# Altium schematic sheet MKIII.SchDoc(MKIII)
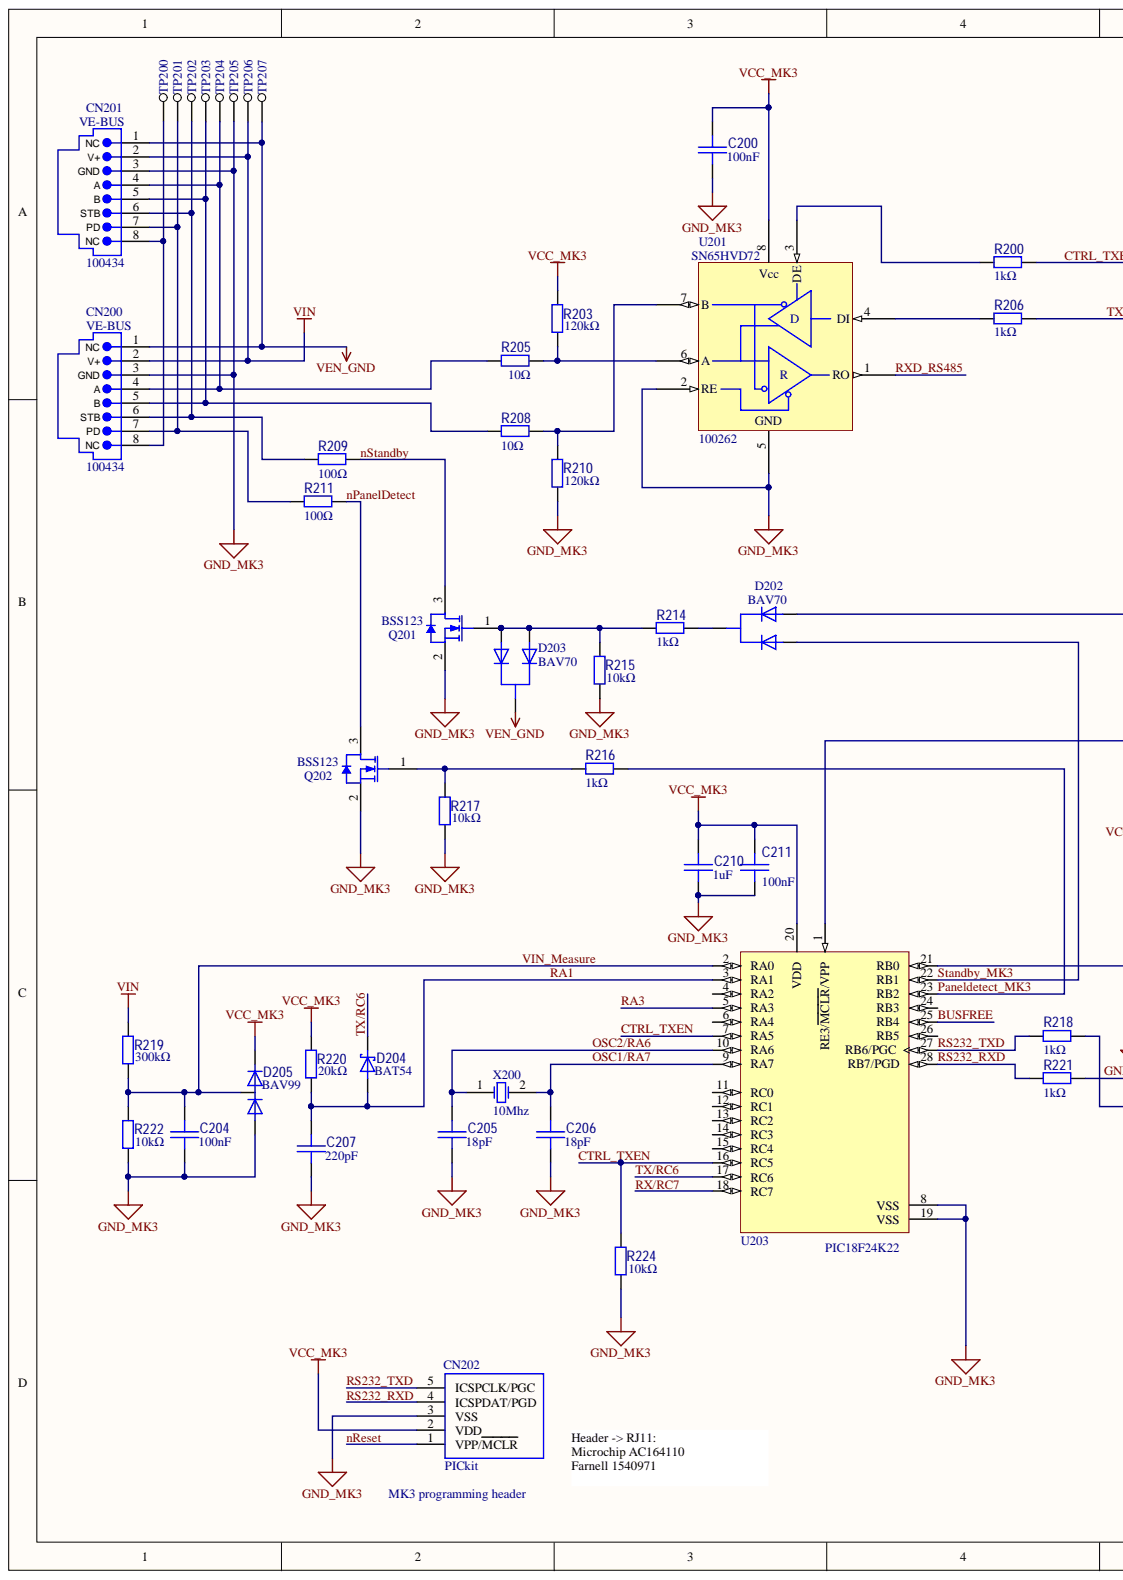
[diagram p0: MKIII.SchDoc, left]
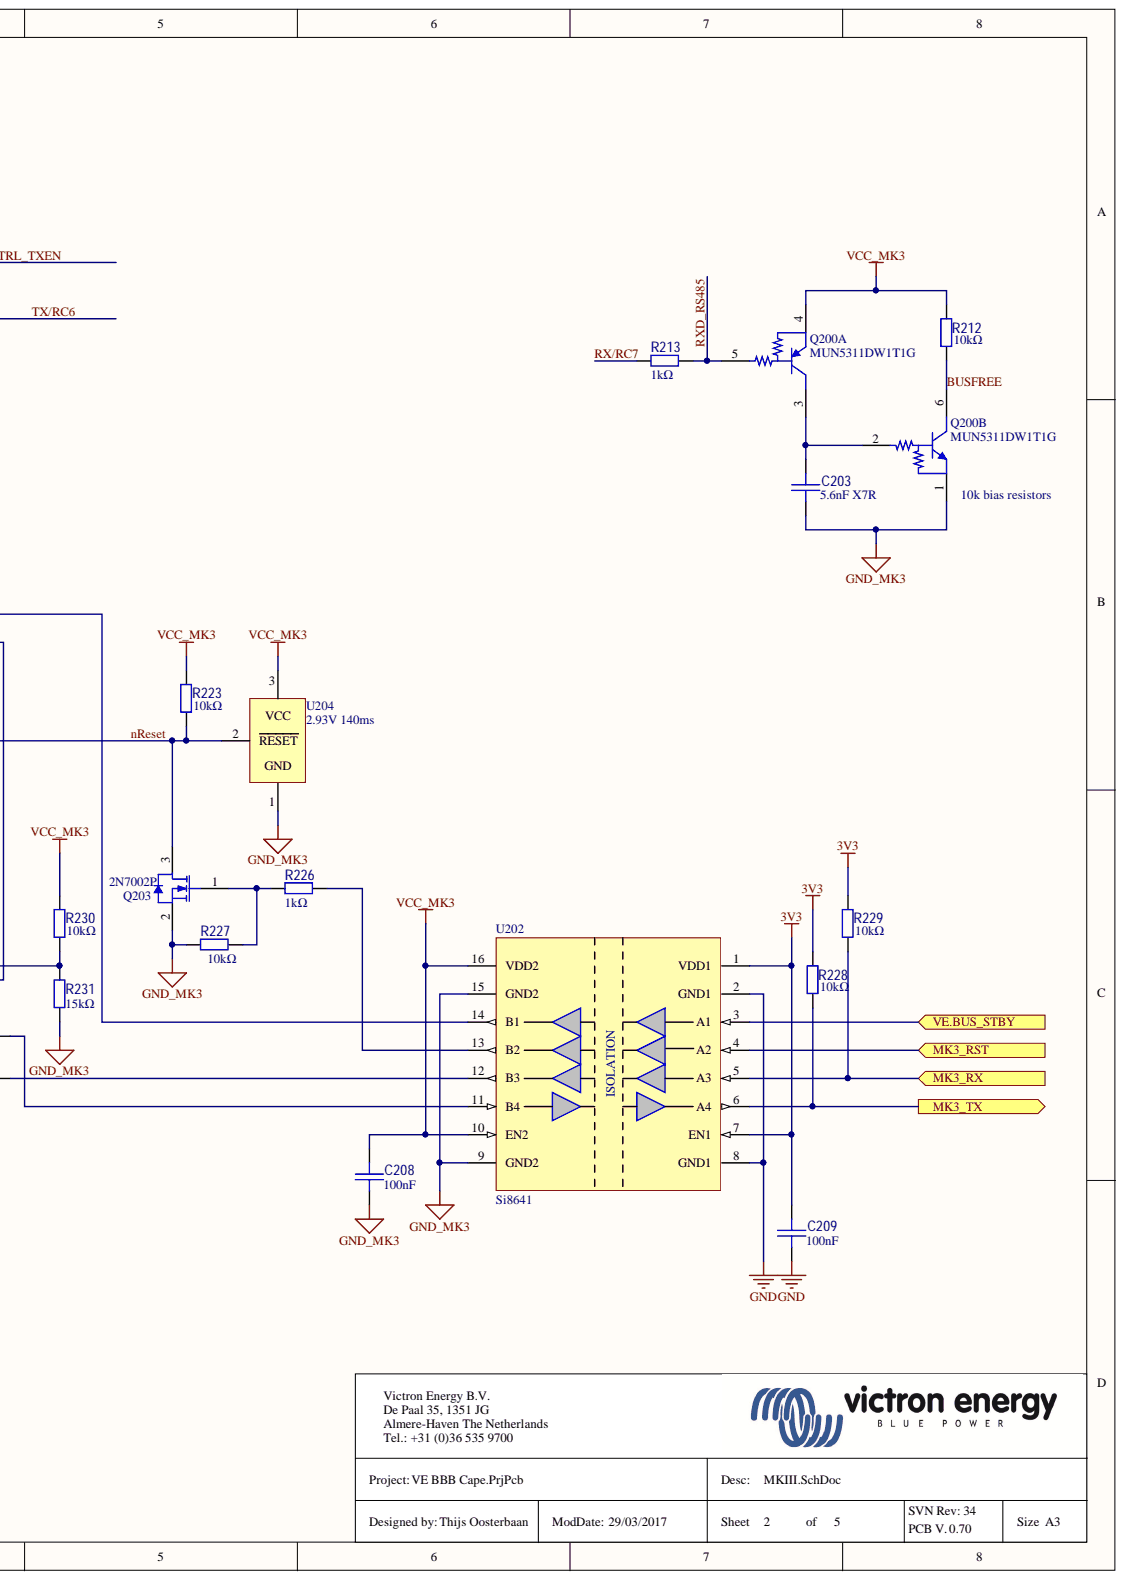
[diagram p1: MKIII.SchDoc, right]

## Parts
C200  value 100nF  [C0805_R]  (hidden comment: 1035)
C203  value 5.6nF X7R  [C0805_R]  (hidden comment: 100325)
C204  value 100nF  [C0805_R]  (hidden comment: 1035)
C205  value 18pF  [R0603_R]  (hidden comment: 1301)
C206  value 18pF  [R0603_R]  (hidden comment: 1301)
C207  value 220pF  [C0805_R]  (hidden comment: 1027)
C208  value 100nF  [C0805_R]  (hidden comment: 1035)
C209  value 100nF  [C0805_R]  (hidden comment: 1035)
C210  value 1uF  [C0805_R]  (hidden comment: 100185)
C211  value 100nF  [C0805_R]  (hidden comment: 1035)
CN200  100434  value VE-BUS  [95001-2881]
CN201  100434  value VE-BUS  [95001-2881]
CN202  value PICkit  [PRGHDR5]  (hidden comment: PICKIT_WITHOUTPIN6)
D202  BAV70  [SOT23_R]
D203  BAV70  [SOT23_R]
D204  BAT54  [SOT23_R]
D205  BAV99  [SOT23_R]
Q200  MUN5311DW1T1G  [SOT363]  (parts A,B)
Q201  BSS123  [SOT23_R]
Q202  BSS123  [SOT23_R]
Q203  2N7002P  [SOT23_R]
R200  value 1kΩ  [R0805_R]  (hidden comment: 372)
R203  value 120kΩ  [R0805_R]  (hidden comment: 422)
R205  value 10Ω  [R0805_R]  (hidden comment: 324)
R206  value 1kΩ  [R0805_R]  (hidden comment: 372)
R208  value 10Ω  [R0805_R]  (hidden comment: 324)
R209  value 100Ω  [R0805_R]  (hidden comment: 348)
R210  value 120kΩ  [R0805_R]  (hidden comment: 422)
R211  value 100Ω  [R0805_R]  (hidden comment: 348)
R212  value 10kΩ  [R0805_R]  (hidden comment: 396)
R213  value 1kΩ  [R0805_R]  (hidden comment: 372)
R214  value 1kΩ  [R0805_R]  (hidden comment: 372)
R215  value 10kΩ  [R0805_R]  (hidden comment: 396)
R216  value 1kΩ  [R0805_R]  (hidden comment: 372)
R217  value 10kΩ  [R0805_R]  (hidden comment: 396)
R218  value 1kΩ  [R0805_R]  (hidden comment: 372)
R219  value 300kΩ  [R0805_R]  (hidden comment: 431)
R220  value 20kΩ  [R0805_R]  (hidden comment: 403)
R221  value 1kΩ  [R0805_R]  (hidden comment: 372)
R222  value 10kΩ  [R0805_R]  (hidden comment: 396)
R223  value 10kΩ  [R0805_R]  (hidden comment: 396)
R224  value 10kΩ  [R0805_R]  (hidden comment: 396)
R226  value 1kΩ  [R0805_R]  (hidden comment: 372)
R227  value 10kΩ  [R0805_R]  (hidden comment: 396)
R228  value 10kΩ  [R0805_R]  (hidden comment: 396)
R229  value 10kΩ  [R0805_R]  (hidden comment: 396)
R230  value 10kΩ  [R0805_R]  (hidden comment: 396)
R231  value 15kΩ  [R0805_R]  (hidden comment: 400)
TP200  CN1  [testpad_round_1mm]  (value not drawn)  (no BOM)
TP201  CN1  [testpad_round_1mm]  (value not drawn)  (no BOM)
TP202  CN1  [testpad_round_1mm]  (value not drawn)  (no BOM)
TP203  CN1  [testpad_round_1mm]  (value not drawn)  (no BOM)
TP204  CN1  [testpad_round_1mm]  (value not drawn)  (no BOM)
TP205  CN1  [testpad_round_1mm]  (value not drawn)  (no BOM)
TP206  CN1  [testpad_round_1mm]  (value not drawn)  (no BOM)
TP207  CN1  [testpad_round_1mm]  (value not drawn)  (no BOM)
U201  100262  value SN65HVD72  [SOIC8]
U202  Si8641  [SO16_R]
U203  PIC18F24K22  [SSOP28_R]
U204  value 2.93V 140ms  [SOT23_R]  (hidden comment: MAX803)
X200  10Mhz  [ABMM2_DATASHEET]

## Ports
MK3_RST, MK3_RX, MK3_TX, VE.BUS_STBY

## Nets
3V3: C209.1 R228.1 R229.1 U202.1(VDD1) U202.7(EN1)
GND: C209.2 U202.2(GND1) U202.8(GND1)
GND_MK3: C200.2 C203.2 C204.2 C205.2 C206.2 C207.2 C208.2 C210.2 C211.2 CN200.3(GND) CN201.3(GND) CN202.3(VSS) D205.1 Q200.1 Q201.2 Q202.2 Q203.2 R210.2 R215.1 R217.1 R222.2 R224.2 R227.1 R231.2 TP205.1 U201.2(RE) U201.5 U202.9(GND2) U202.15(GND2) U203.8(VSS) U203.19(VSS) U204.1
VCC_MK3: C200.1 C208.1 C210.1 C211.1 CN202.2(VDD) D205.2 Q200.4 R203.1 R212.1 R220.1 R223.1 R230.1 U201.8 U202.10(EN2) U202.16(VDD2) U203.20(VDD) U204.3
VEN_GND: CN200.1(NC) CN201.1(NC) D203.3 TP207.1
VIN: CN200.2(V+) CN201.2(V+) R219.1 TP206.1
BUSFREE: Q200.6 R212.2 U203.25(RB4)
CTRL_TXEN: R200.1 R224.1 U203.7(RA5) U203.16(RC5)
MK3_RST: U202.4(A2) ; port MK3_RST
MK3_RX: R229.2 U202.5(A3) ; port MK3_RX
MK3_TX: R228.2 U202.6(A4) ; port MK3_TX
nPanelDetect: Q202.3 R211.2
nReset: CN202.1(VPP/MCLR) Q203.3 R223.2 U203.1(RE3/MCLR/VPP) U204.2
nStandby: Q201.3 R209.2
OSC1/RA7: C206.1 U203.9(RA7) X200.2
OSC2/RA6: C205.1 U203.10(RA6) X200.1
Paneldetect_MK3: R216.1 U203.23(RB2)
RA1: C207.1 D204.1 R220.2 U203.3(RA1)
RA3: U203.5(RA3)
RS232_RXD: CN202.4(ICSPDAT/PGD) R221.2 U203.28(RB7/PGD)
RS232_TXD: CN202.5(ICSPCLK/PGC) R218.2 U203.27(RB6/PGC)
RX/RC7: R213.2 U203.18(RC7)
RXD_RS485: Q200.5 R213.1 U201.1(RO)
Standby_MK3: D202.1 U203.22(RB1)
TX/RC6: D204.3 R206.1 U203.17(RC6)
VE.BUS_STBY: U202.3(A1) ; port VE.BUS_STBY
VIN_Measure: C204.1 D205.3 R219.2 R222.1 U203.2(RA0)
NetC203_1: C203.1 Q200.2 Q200.3
NetCN200_4: CN200.4(A) CN201.4(A) R205.1 TP204.1
NetCN200_5: CN200.5(B) CN201.5(B) R208.1 TP203.1
NetCN200_6: CN200.6(STB) CN201.6(STB) R209.1 TP202.1
NetCN200_7: CN200.7(PD) CN201.7(PD) R211.1 TP201.1
NetCN200_8: CN200.8(NC) CN201.8(NC) TP200.1
NetD202_2: D202.2 U202.14(B1)
NetD202_3: D202.3 R214.1
NetD203_1: D203.1 D203.2 Q201.1 R214.2 R215.2
NetQ202_1: Q202.1 R216.2 R217.2
NetQ203_1: Q203.1 R226.2 R227.2
NetR200_2: R200.2 U201.3(DE)
NetR203_2: R203.2 R205.2 U201.6(A)
NetR206_2: R206.2 U201.4(DI)
NetR208_2: R208.2 R210.1 U201.7(B)
NetR218_1: R218.1 U202.11(B4)
NetR221_1: R221.1 U202.12(B3)
NetR226_1: R226.1 U202.13(B2)
NetR230_2: R230.2 R231.1 U203.21(RB0)

Not connected: U203 4(RA2) 6(RA4) 11(RC0) 12(RC1) 13(RC2) 14(RC3) 15(RC4) 24(RB3) 26(RB5)

## Library data of the parts
C200 C204 C208 C209 C211 = 1035: Part_Description: CAP, SMD 0805 100nF 50V X7R 10%, Yageo; MANUFACTURER: Yageo; MAN_PARTNUMBER: CC0805KPX7R9BB104; INDIC_PARTNO: CSE100NF0805YAGY; Voltage: 50V; VENDOR_STOCK_NR: 603-CC805KPX7R9BB104; VENDOR_PRICE: 0.005; VENDOR: Mouser; Tol: 0.1; Shape: 805; INDIC_PRICE: 0.0682; Database Table Name: COMPDATA$; Cls: CAP; Part: 1035; Component Kind: Standard; Library Name: Data Base Librarys.DbLib
C203 = 100325: Part_Description: Cap, MLCC, X7R, 50V, 10%, 0805, 5.6nF; MANUFACTURER: Kemet; MAN_PARTNUMBER: C0805C562K5RACTU; VENDOR_STOCK_NR: 399-1156-1-ND; VENDOR_PRICE: 0.03025; VENDOR: Digikey; Database Table Name: COMPDATA$; Cls: CAP; Part: 100325; Component Kind: Standard; Library Name: Data Base Librarys.DbLib
C205 C206 = 1301: Part_Description: Cap, MLC, 0603, 18pF, 10%, X7R; MANUFACTURER: YAGEO; MAN_PARTNUMBER: CC0603JRNPO9BN180; VENDOR_STOCK_NR: 721992; VENDOR_PRICE: 0.0299; VENDOR: Farnell; Database Table Name: COMPDATA$; Cls: CAP; Part: 1301; Component Kind: Standard; Library Name: Data Base Librarys.DbLib
C207 = 1027: Part_Description: CAP,MLC,X7R,220PF,50V, 0805; MANUFACTURER: YAGEO; MAN_PARTNUMBER: 223858015614; INCAP_PARTNO: 1005040197; Voltage: 50V; VENDOR_STOCK_NR: 311-1123-2-ND; VENDOR_PRICE: 0.00901; VENDOR: Digikey; Tol: 0.1; Shape: 805; Database Table Name: COMPDATA$; Cls: CAP; Part: 1027; ComponentLink1Description: \datasheets\Yageo-2238_580_15649-datasheet.pdf; Component Kind: Standard; Library Name: Data Base Librarys.DbLib
C210 = 100185: Part_Description: Cap, MLCC, X7R, 16V, 10%, 0805, 1uF; MANUFACTURER: Kemet; MAN_PARTNUMBER: C0805C105K4RACTU; VENDOR_STOCK_NR: 399-1284-1-ND; VENDOR_PRICE: 0.0222; VENDOR: Digikey; Database Table Name: COMPDATA$; Cls: CAP; Part: 100185; Component Kind: Standard; Library Name: Data Base Librarys.DbLib
CN200 CN201 = 100434: RJ45-VEBUS; Part_Description: VE-BUS RJ45  connector; MANUFACTURER: Molex; MAN_PARTNUMBER: 955012881; VENDOR_STOCK_NR: WM5571-ND; VENDOR_PRICE: 0.22; VENDOR: Arie; INCAP_PRICE: 0.308; Database Table Name: COMPDATA$; Cls: CON; Part: 100434; Component Kind: Standard; Library Name: Data Base Librarys.DbLib
CN202 = 100319: Part_Description: PICkit 2/3 Connector without pin 6; MANUFACTURER: Harwin; MAN_PARTNUMBER: M20-9770546; VENDOR_STOCK_NR: 952-1794-ND; VENDOR_PRICE: 0.08745; VENDOR: Digikey; Database Table Name: COMPDATA$; Cls: CON; Part: 100319; Component Kind: Standard; Library Name: Data Base Librarys.DbLib
D202 D203 = 4000: Part_Description: DIODE,70V 0.2A,BAV70,SOT23DDCK; MANUFACTURER: DIOTEK; INDIC_PARTNO: DDSAOBAV70VEAXX; INCAP_PARTNO: 1009100238; ACM_PARTNO: 9375; Voltage: 70V; VENDOR_STOCK_NR: BAV70LT3GOSTR-ND; VENDOR_PRICE: 0.015; VENDOR: Digikey; INDIC_PRICE: 0.021; INCAP_PRICE: 0.0094; Database Table Name: COMPDATA$; Cls: DIO; ACM_PRICE: 0.0249; Value: BAV70; Part: 4000; MAN_PARTNUMBER: BAV70; ComponentLink1Description: \datasheets\49363_vmn-pt9161.pdf; Component Kind: Standard; Library Name: Data Base Librarys.DbLib
D204 = 4002: Part_Description: DIODE,30V 0.2A,SOT23DDCK,BAT54; MANUFACTURER: NXP; INDIC_PARTNO: DDSMDSOTBAT54NXY; VENDOR_STOCK_NR: 1081190; VENDOR_PRICE: 0.034; VENDOR: Farnell; INDIC_PRICE: 0.0806; Database Table Name: COMPDATA$; Cls: DIO; Value: BAT54; Part: 4002; MAN_PARTNUMBER: BAT54; Component Kind: Standard; Library Name: Data Base Librarys.DbLib
D205 = 4001: Part_Description: DIODE, BAV99; MANUFACTURER: Fairchild; IRRICHI_PARTNO: 2403327470000; INDIC_PARTNO: DDSANBAV99YN/XX; INCAP_PARTNO: 1009100528; VENDOR_STOCK_NR: BAV99LT1GOSTR-ND; VENDOR_PRICE: 0.02091; VENDOR: Digikey; IRRICHI_PRICE: 0.0171; INDIC_PRICE: 0.026; INCAP_PRICE: 0.0192; Database Table Name: COMPDATA$; Cls: DIO; Value: BAV99; Part: 4001; MAN_PARTNUMBER: BAV99; Component Kind: Standard; Library Name: Data Base Librarys.DbLib
Q200 = 101521: Bipolar Pre-Biased / Digital Transistor, BRT, 50 V, 100 mA, 10 kohm, 10 kohm, 1, SOT-363; MANUFACTURER: ON SEMICONDUCTOR; Database Table Name: AllComponents; Cls: TRAN; Value: MUN5311DW1T1G; Supplier Price 1: 0,0234; Supplier Part Number 1: 2317908; Supplier 1: Farnell; PartID: 101521; Part: 101521; MAN_PARTNUMBER: MUN5311DW1T1G; Component Kind: Standard; Library Name: VictronAltiumComponents.SVNDBLib
Q201 Q202 = 100226: Part_Description: NMOS, BSS123, 100V, 170mA, SOT23; MANUFACTURER: Fairchild; VENDOR_STOCK_NR: BSS123NCT-ND; VENDOR_PRICE: 0.046; VENDOR: Digikey; Database Table Name: COMPDATA$; Cls: NMOS; Value: BSS123; Part: 100226; MAN_PARTNUMBER: BSS123; Component Kind: Standard; Library Name: Data Base Librarys.DbLib
Q203 = 100220: Part_Description: NMOS, 2N7002P, 60V, 360mA, SOT23; MANUFACTURER: NXP; MAN_PARTNUMBER: 2N7002P,215; VENDOR_STOCK_NR: 568-5818-2-ND; VENDOR_PRICE: 0.02423; VENDOR: Digikey; Database Table Name: COMPDATA$; Cls: NMOS; Value: 2N7002P; Part: 100220; Component Kind: Standard; Library Name: Data Base Librarys.DbLib
R200 R206 R213 R214 R216 R218 R221 R226 = 372: Part_Description: RES,MF,1K,  0,125W, 1% 0805; MANUFACTURER: Yageo; MAN_PARTNUMBER: RC0805FR-071KL; INDIC_PARTNO: RSCE1K00XFB8NYX; INCAP_PARTNO: 1035000320; VENDOR_STOCK_NR: 603-RC0805FR-071KL; VENDOR_PRICE: 0.003; VENDOR: Mouser; Tol: 0.01; Shape: 805; Power: 0,125W; INDIC_PRICE: 0.0023; INCAP_PRICE: 0.0014; Database Table Name: COMPDATA$; Cls: RES; Part: 372; ComponentLink1Description: \datasheets\Yageo-RC0805FR-0710KL-datasheet.pdf; Component Kind: Standard; Library Name: Data Base Librarys.DbLib
R203 R210 = 422: Part_Description: RES,MF,120K, 0.125W 1% 0805; MANUFACTURER: YAGEO; MAN_PARTNUMBER: RC0805FR-07120KL; INDIC_PARTNO: RSCE120KXFB8YAGY; VENDOR_STOCK_NR: 603-RC0805FR-07120KL; VENDOR_PRICE: 0.003; VENDOR: Mouser; Tol: 0.01; Shape: 805; Power: 0,125W; INDIC_PRICE: 0.0023; INCAP_PRICE: 0.0014; Database Table Name: COMPDATA$; Cls: RES; Part: 422; ComponentLink1Description: \datasheets\Yageo-RC0805FR-0710KL-datasheet.pdf; Component Kind: Standard; Library Name: Data Base Librarys.DbLib
R205 R208 = 324: Part_Description: RES,MF,10E, 0.125W 1% 0805; MANUFACTURER: YAGEO; MAN_PARTNUMBER: RC0805FR-0710RL; INDIC_PARTNO: RSCE00010RAYAGY; INCAP_PARTNO: 1035031265; VENDOR_STOCK_NR: 603-RC0805FR-0710RL; VENDOR_PRICE: 0.003; VENDOR: Mouser; Tol: 0.01; Shape: 805; Power: 0,125W; INDIC_PRICE: 0.0018; INCAP_PRICE: 0.0014; Database Table Name: COMPDATA$; Cls: RES; Part: 324; ComponentLink1Description: \datasheets\Yageo-RC0805FR-0710KL-datasheet.pdf; Component Kind: Standard; Library Name: Data Base Librarys.DbLib
R209 R211 = 348: Part_Description: RES,MF,100E, 0.125W 1% 0805; MANUFACTURER: YAGEO; MAN_PARTNUMBER: RC0805FR-07100RL; INDIC_PARTNO: RSCE00100RFB0YAY; VENDOR_STOCK_NR: 603-RC0805FR-07100RL; VENDOR_PRICE: 0.003; VENDOR: Mouser; Tol: 0.01; Shape: 805; Power: 0,125W; INDIC_PRICE: 0.0023; INCAP_PRICE: 0.0014; Database Table Name: COMPDATA$; Cls: RES; Part: 348; ComponentLink1Description: \datasheets\Yageo-RC0805FR-0710KL-datasheet.pdf; Component Kind: Standard; Library Name: Data Base Librarys.DbLib
R212 R215 R217 R222-R224 R227-R230 = 396: Part_Description: RES,MF,10K  0.125W 1%0805; MANUFACTURER: YAGEO; MAN_PARTNUMBER: RC0805FR-0710KL; INDIC_PARTNO: RSCE00010KFB7YAY; INCAP_PARTNO: 1035031290; VENDOR_STOCK_NR: 603-RC0805FR-0710KL; VENDOR_PRICE: 0.003; VENDOR: Mouser; Tol: 0.01; Shape: 805; Power: 0,125W; INDIC_PRICE: 0.0018; INCAP_PRICE: 0.0014; Database Table Name: COMPDATA$; Cls: RES; Part: 396; ComponentLink1Description: \datasheets\Yageo-RC0805FR-0710KL-datasheet.pdf; Component Kind: Standard; Library Name: Data Base Librarys.DbLib
R219 = 431: Part_Description: RES,MF,300K, 0.125W 1% 0805; MANUFACTURER: YAGEO; MAN_PARTNUMBER: RC0805FR-07300KL; VENDOR_STOCK_NR: 603-RC0805FR-07300KL; VENDOR_PRICE: 0.003; VENDOR: Mouser; Tol: 0.01; Shape: 805; Power: 0,125W; INCAP_PRICE: 0.0014; Database Table Name: COMPDATA$; Cls: RES; Part: 431; ComponentLink1Description: \datasheets\Yageo-RC0805FR-0710KL-datasheet.pdf; Component Kind: Standard; Library Name: Data Base Librarys.DbLib
R220 = 403: Part_Description: RES,MF,20k, 0.125W 1% 0805; MANUFACTURER: YAGEO; MAN_PARTNUMBER: RC0805FR-0720KL; VENDOR_STOCK_NR: 603-RC0805FR-0720KL; VENDOR_PRICE: 0.003; VENDOR: Mouser; Tol: 0.01; Shape: 805; Power: 0,125W; INCAP_PRICE: 0.0014; Database Table Name: COMPDATA$; Cls: RES; Part: 403; ComponentLink1Description: \datasheets\Yageo-RC0805FR-0710KL-datasheet.pdf; Component Kind: Standard; Library Name: Data Base Librarys.DbLib
R231 = 400: Part_Description: RES,MF,15K  0.125W 1% 0805; MANUFACTURER: YAGEO; MAN_PARTNUMBER: RC0805FR-0715KL; INDIC_PARTNO: RSCE00015KFB7YAY; INCAP_PARTNO: 1035031291; Tol: 0.01; Shape: 805; Power: 0,125W; INDIC_PRICE: 0.0015; INCAP_PRICE: 0.0014; Database Table Name: COMPDATA$; Cls: RES; Part: 400; ComponentLink1Description: \datasheets\Yageo-RC0805FR-0710KL-datasheet.pdf; Component Kind: Standard; Library Name: Data Base Librarys.DbLib
TP200-TP207 = 100320: Testpad, Round, D=1mm; Database Table Name: Connector; Cls: CON; PartID: 100320; Part: 100320; Library Name: VictronAltiumComponents.SVNDBLib
U201 = 100262: Part_Description: RS485 Transceiver, SN65HVD72, 3.3V, 250kbps; MANUFACTURER: TI; MAN_PARTNUMBER: SN65HVD72DR; VENDOR_STOCK_NR: 296-39179-1-ND; VENDOR_PRICE: 0.7875; VENDOR: Digikey; Database Table Name: COMPDATA$; Cls: IC; Part: 100262; Component Kind: Standard; Library Name: Data Base Librarys.DbLib
U202 = 101515: IC, digital isolator, Si8641; MANUFACTURER: Silabs; MAN_PARTNUMBER: Si8641BB-B-IS1; Shape: SO; Database Table Name: AllComponents; Value: Si8641; Supplier Price 1: 2,07; Supplier Part Number 1: 336-2566-ND; Supplier 1: Digikey; PartID: 101515; Part: 101515; Component Kind: Standard; Cls: IC; Library Name: VictronAltiumComponents.SVNDBLib
U203 = 101558: IC, Victron MK3 microcontroller; MANUFACTURER: MICROCHIP; MAN_PARTNUMBER: SEC014900000; Shape: SSOP28; Database Table Name: AllComponents; Value: PIC18F24K22; Supplier Price 1: 0,17; Supplier Part Number 1: SEC014900000; Supplier 1: Victron; PartID: 101558; Part: 101558; Library Name: VictronAltiumComponents.SVNDBLib; INCAP_PARTNO: SEC014900000; ComponentLink1URLSrc: http://www.farnell.com/datasheets/1639160.pdf; Component Kind: Standard; Cls: IC
U204 = 101827: IC, reset monitor, 2.93V, 140ms, SOT-23, NCP803, open drain; MANUFACTURER: ON Semiconductor; MAN_PARTNUMBER: NCP803SN293T1G; Shape: SOT23; Supplier Price 1: 0,22138; Supplier Part Number 1: NCP803SN293T1GOSCT-ND; Supplier 1: Digikey; PartID: 101827; Part: 101827; Database Table Name: IC; Component Kind: Standard; Cls: IC; Library Name: VictronAltiumComponents.SVNDBLib
X200 = 100313: Crystal Unit; Part_Description: Xtal, 10Mhz, Abbracon, ABMM2-10.000MHZ-E2-T, Datasheet Recommended Footprint; MANUFACTURER: Abbracon; MAN_PARTNUMBER: ABMM2-10.000MHZ-E2-T; VENDOR_STOCK_NR: 1611798; VENDOR_PRICE: 0.531; VENDOR: Farnell; Database Table Name: COMPDATA$; Cls: XTAL; Value: 10Mhz; Part: 100313; ComponentLink1Description: \datasheets\ABMM2.pdf; Component Kind: Standard; Library Name: Data Base Librarys.DbLib
